# Altium schematic sheet Power.SchDoc(Power)
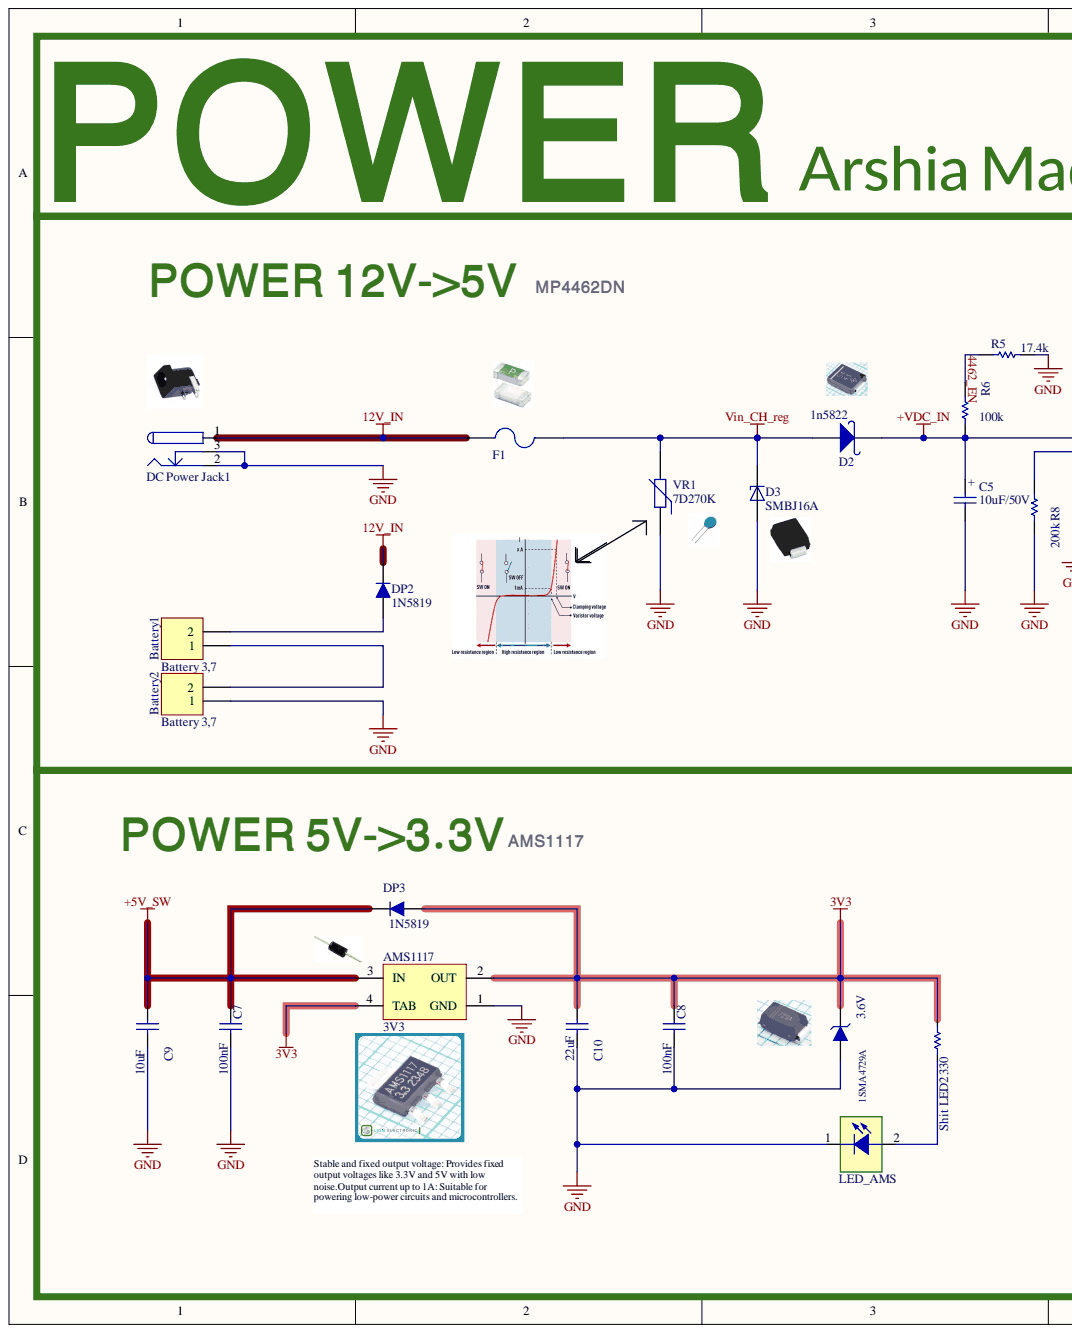
[diagram p0: Power.SchDoc, left]
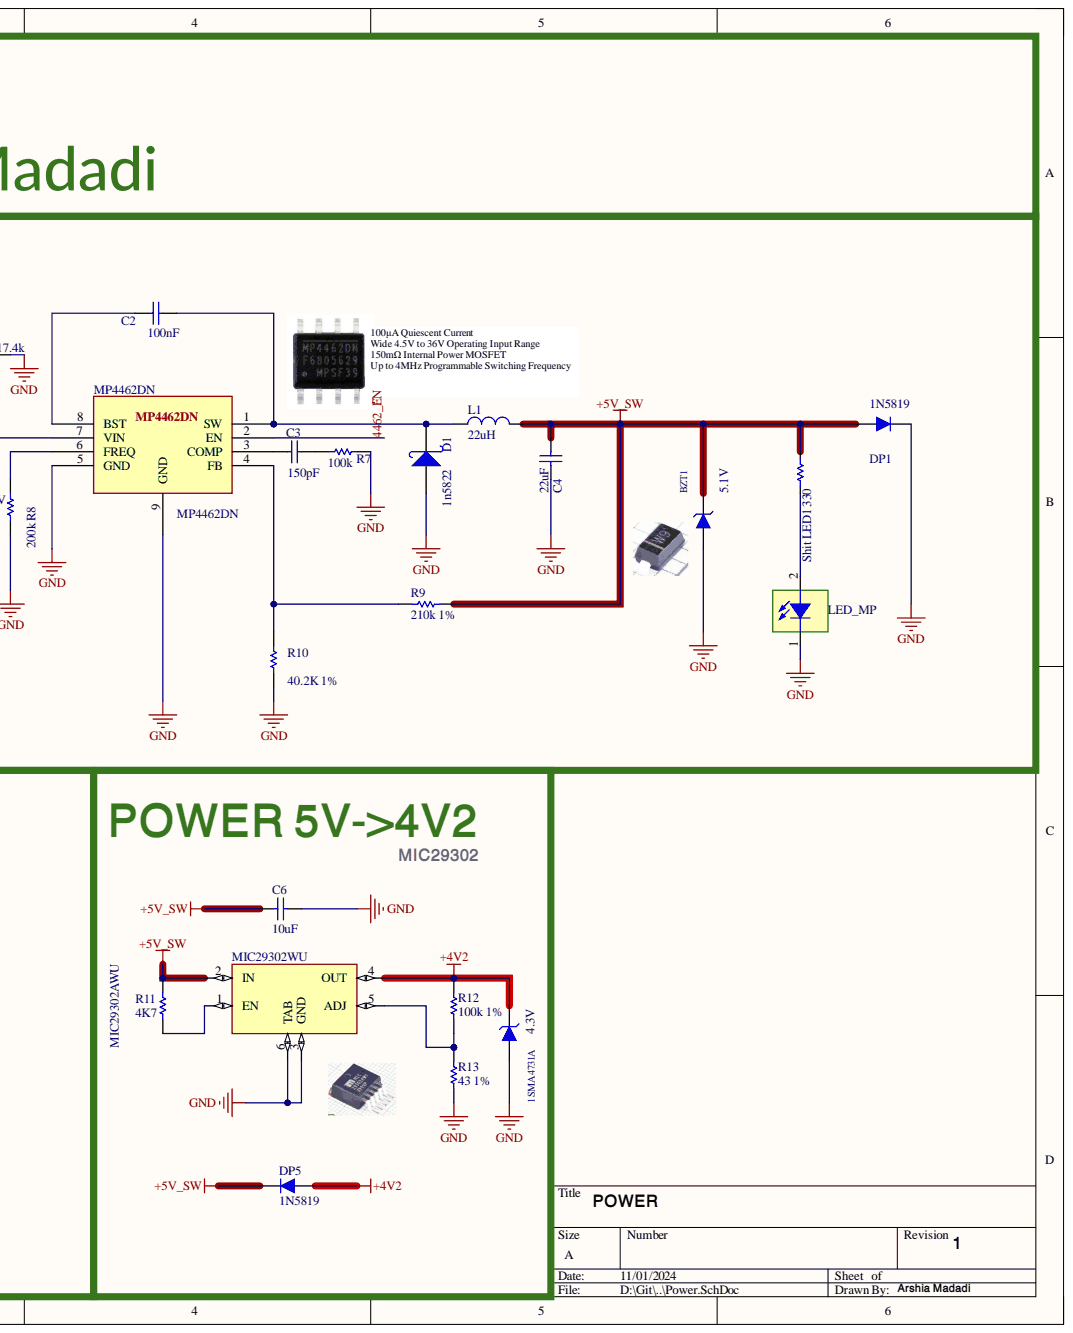
[diagram p1: Power.SchDoc, right]

## Parts
1SMA4729A  3.6V  [SOD123]
1SMA4731A  4.3V  [SOD123]
AMS1117  3V3  [SOT223_M]
Battery1  Battery 3,7  [HDR1X2]
Battery2  Battery 3,7  [HDR1X2]
BZT1  5.1V  [SOD123]
C2  100nF  [CAP1206]
C3  150pF  [CAP1206]
C4  22uF  [CAP1206]
C5  10uF/50V  [MY_cap_smd10uf]
C6  10uF  [CAP1206]
C7  100nF  [CAPC4817X12N]
C8  100nF  [CAPC4817X12N]
C9  10uF  [CAP1206]
C10  22uF  [CAP1206]
D1  1n5822  [DO-214AB(SMC)]
D2  1n5822  [DO-214AB(SMC)]
D3  SMBJ16A  [DIOM4336X265N]
DC_Power_Jack1  DC Power Jack  [DC Power Jack 1]
DP1  1N5819  [1n58XX DO-201AD]
DP2  1N5819  [1n58XX DO-201AD]
DP3  1N5819  [1n58XX DO-201AD]
DP5  1N5819  [430X270D]
F1  F=3.0 A  [1206 FUSE]  (value not drawn)
L1  value 22uH  [0805 - big]  (hidden comment: 10uH)
LED_AMS  SMD 1206 LED  [LED 1206]  (value not drawn)
LED_MP  SMD 1206 LED  [LED 1206]  (value not drawn)
MIC29302WU  MIC29302AWU  [TO263-5]
MP4462DN  MP4462DN  [PCBComponent_1 - duplicate]
R5  17.4k  [RESC4817X04N]
R6  100k  [RESC4817X04N]
R7  100k  [RESC4817X04N]
R8  200k  [RESC4817X04N]
R9  210k 1%  [RESC4817X04N]
R10  40.2K 1%  [RESC4817X04N]
R11  4K7  [RESC4817X04N]
R12  100k 1%  [RESC4817X04N]
R13  43 1%  [RESC4817X04N]
Shit_LED1  330  [1206_Res]
Shit_LED2  330  [1206_Res]  (value not drawn)
VR1  7D270K  [07D XXX]

## Nets
3V3: 1SMA4729A.2 AMS1117.2(OUT) AMS1117.4(TAB) C8.2 C10.1 DP3.1 Shit_LED2.2
12V_IN: DC_Power_Jack1.1 DP2.2 F1.1
+4V2: 1SMA4731A.2 DP5.1 MIC29302WU.4(OUT) R12.2
+5V_SW: AMS1117.3(IN) BZT1.2 C4.1 C6.1 C7.2 C9.1 DP1.1 DP3.2 DP5.2 L1.2 MIC29302WU.2(IN) R9.2 R11.2 Shit_LED1.2
+VDC_IN: C5.+ D2.2 MP4462DN.7(VIN) R6.2
GND: 1SMA4729A.1 1SMA4731A.1 AMS1117.1(GND) Battery2.1 BZT1.1 C4.2 C5.- C6.2 C7.1 C8.1 C9.2 C10.2 D1.1 D3.A DC_Power_Jack1.2 DC_Power_Jack1.3 DP1.2 LED_AMS.1 LED_MP.1 MIC29302WU.3(GND) MIC29302WU.6(TAB) MP4462DN.5(GND) MP4462DN.9(GND) R5.2 R7.2 R8.1 R10.1 R13.1 VR1.1
Vin_CH_reg: D2.1 D3.C F1.2 VR1.2
4462_EN: MP4462DN.2(EN) R5.1 R6.1
NetBattery1_1: Battery1.1 Battery2.2
NetBattery1_2: Battery1.2 DP2.1
NetC2_1: C2.1 D1.2 L1.1 MP4462DN.1(SW)
NetC2_2: C2.2 MP4462DN.8(BST)
NetC3_1: C3.1 R7.1
NetC3_2: C3.2 MP4462DN.3(COMP)
NetLED_AMS_2: LED_AMS.2 Shit_LED2.1
NetLED_MP_2: LED_MP.2 Shit_LED1.1
NetMIC29302WU_1: MIC29302WU.1(EN) R11.1
NetMIC29302WU_5: MIC29302WU.5(ADJ) R12.1 R13.2
NetMP4462DN_4: MP4462DN.4(FB) R9.1 R10.2
NetMP4462DN_6: MP4462DN.6(FREQ) R8.2

## Library data of the parts
1SMA4729A 1SMA4731A BZT1 = ZENER GENERAL DIODE: DIODE ZENER GENERAL; VALUE: 12V; Component Kind: Standard; ComponentLink1Description: Manufacturer Link; ComponentLink2Description: Datasheet; Library Name: Altium.IntLib; PackageDescription: DIODE GEN ZENER; PackageReference: DO 35; PackageVersion: 2017-1-12; Published: 2017-1-12; Publisher: Abbas Basereh
AMS1117 = AMS1117-x.x: x.x Volt, 800mA Low-Dropout Linear Regulator, 4-pin SOT-223; Designer: Ali Hafizi; Component Kind: Standard; Data: 16-12-2016; Library Name: Altium.IntLib
Battery1 Battery2 = Header 2: Header, 2-Pin; Component Kind: Standard; LatestRevisionDate: 17-Jul-2002; LatestRevisionNote: Re-released for DXP Platform.; Library Name: Sajad_Altium_Library.IntLib; Publisher: Altium Limited
C2 = CAP SMD PACKAGE: TOTAL CAPACITOR SMD PACK; Desc.: CAP SMD TOTAL PACKAGES; Designer: Abbas Basereh; Component Kind: Standard; Data: 2017-3-10; Library Name: Altium.IntLib; Signal Integrity: CAP SMD; VALUE: 100nF
C3 C4 C6 C9 C10 = CAP SMD PACKAGE: TOTAL CAPACITOR SMD PACK; Desc.: CAP SMD TOTAL PACKAGES; Designer: Abbas Basereh; VALUE: 100nF; Component Kind: Standard; Data: 2017-3-10; Library Name: Altium.IntLib; Signal Integrity: CAP SMD
C5 = cap_smd_10u: Component Kind: Standard; Library Name: AMINLIB.IntLib
C7 C8 = CAP SMD 1206: CAP 1206; Designer: Abbas Basereh; Component Kind: Standard; Data: 16-12-2016; Desc.: CAP SMD 1206; Library Name: Altium.IntLib
D1 D2 = 1N5822 SMD: Schottky Rectifiers; Manufacturer: ON Semiconductor/Fairchild; Part. No: SS34; Designer: Abbas Basereh; Component Kind: Standard; Data: 16-12-2016; Library Name: Altium.IntLib; Link: https://www.aliexpress.com/item/Free-shipping-100pcs-1n5822-sma-smd-do-214ac-Schottky-diode-ss34/32337482329.html?spm=2114.01010208.3.1.zLZDsP&ws_ab_test=searchweb0_0,searchweb201602_4_10065_10068_10084_10083_10080_10082_10081_10060_10061_10062_10056_10055_10054_10059_10099_10078_10079_427_10073_10103_10102_10096_10052_10050_10051,searchweb201603_4&btsid=6e50dc92-ac69-45bc-a19e-209af19ce602
D3 = SMBJ16A: 600W, 18.8V, 5%, Unidirectional, TVS; MF: Taiwan Semiconductor; Availability: In Stock; Check_prices: https://www.snapeda.com/parts/SMBJ16A/Taiwan+Semiconductor/view-part/?ref=eda; Component Kind: Standard; Library Name: SMBJ16A.IntLib; MP: SMBJ16A; Package: SMD-2-2 Avago; Price: None; Purchase-URL: https://pricing.snapeda.com/search/part/SMBJ16A/?ref=eda; SnapEDA_Link: https://www.snapeda.com/parts/SMBJ16A/Taiwan+Semiconductor/view-part/?ref=snap
DC_Power_Jack1 = DC Power Jack: DC Power Jack. Center Pin 2.5mm. Diameter: 5.7mm; Company: Personal; Designer: Ali Hafizi; Project Name: Laser; Adding Date: 10/21/2009; Component Kind: Standard; Library Name: Altium.IntLib
DP1-DP3 DP5 = 1N5819: Schottkey Rectifiers; Designer: Abbas Basereh; Component Kind: Standard; Data: 16-12-2016; Library Name: Altium.IntLib
F1 = Fuse SMD1206: SMD Fuses 1206; Designer: Abbas Basereh; Component Kind: Standard; Data: 16-12-2016; Desc.: SMD Fuses 1206; Library Name: Altium.IntLib
L1 = Inductor: Code_IEC: 1005; Code_JEITA: 0402; Component Kind: Standard; Description: Inductor; LatestRevisionDate: 17-Jul-2002; LatestRevisionNote: Re-released for DXP Platform.; PackageReference: 0402-A; Published: 8-Jun-2000; Library Name: Sajad_Altium_Library.IntLib; Publisher: Altium Limited
LED_AMS LED_MP = LED SMD 1206: Component Kind: Standard; Description: SMD 1206 LED; Library Name: Altium.IntLib
MIC29302WU = MIC29302AWU: Linear Voltage Regulator IC Positive Adjustable 1 Output 3A TO-263-5; MF: Microchip; Check_prices: https://www.snapeda.com/parts/MIC29302AWU/Microchip/view-part/?ref=eda; Component Kind: Standard; Library Name: MIC29302AWU.IntLib; MP: MIC29302AWU; Package: TO-263-5 Microchip; SnapEDA_Link: https://www.snapeda.com/parts/MIC29302AWU/Microchip/view-part/?ref=snap
R5-R13 = RES 1206 0.25W: RES 1206; Desc.: RES SMD 1206; Designer: Abbas Basereh; Component Kind: Standard; Data: 16-12-2016; Library Name: Altium.IntLib
Shit_LED1 Shit_LED2 = Res: Resistor; Component Kind: Standard; LatestRevisionDate: 17-Jul-2002; LatestRevisionNote: Re-released for DXP Platform.; PackageReference: AXIAL-0.3; Published: 8-Jun-2000; Library Name: Sajad_Altium_Library.IntLib; Publisher: Altium Limited
VR1 = VARISTOR (VDR): VARISTORS; Manufacturer: china-canada-philipina; Designer: Abbas Basereh; Models: MOV-VDR-COV; VALUE: xxDxxx; Component Kind: Standard; Data: 2017-1-5; Library Name: Altium.IntLib; Link: digikey.com; PUBLISHER: ABBAS BASEREH
MP4462DN = MP4462DN: Component Kind: Standard; Library Name: MP4462D.SchLib
